# Altium schematic sheet Sch_front.SchDoc
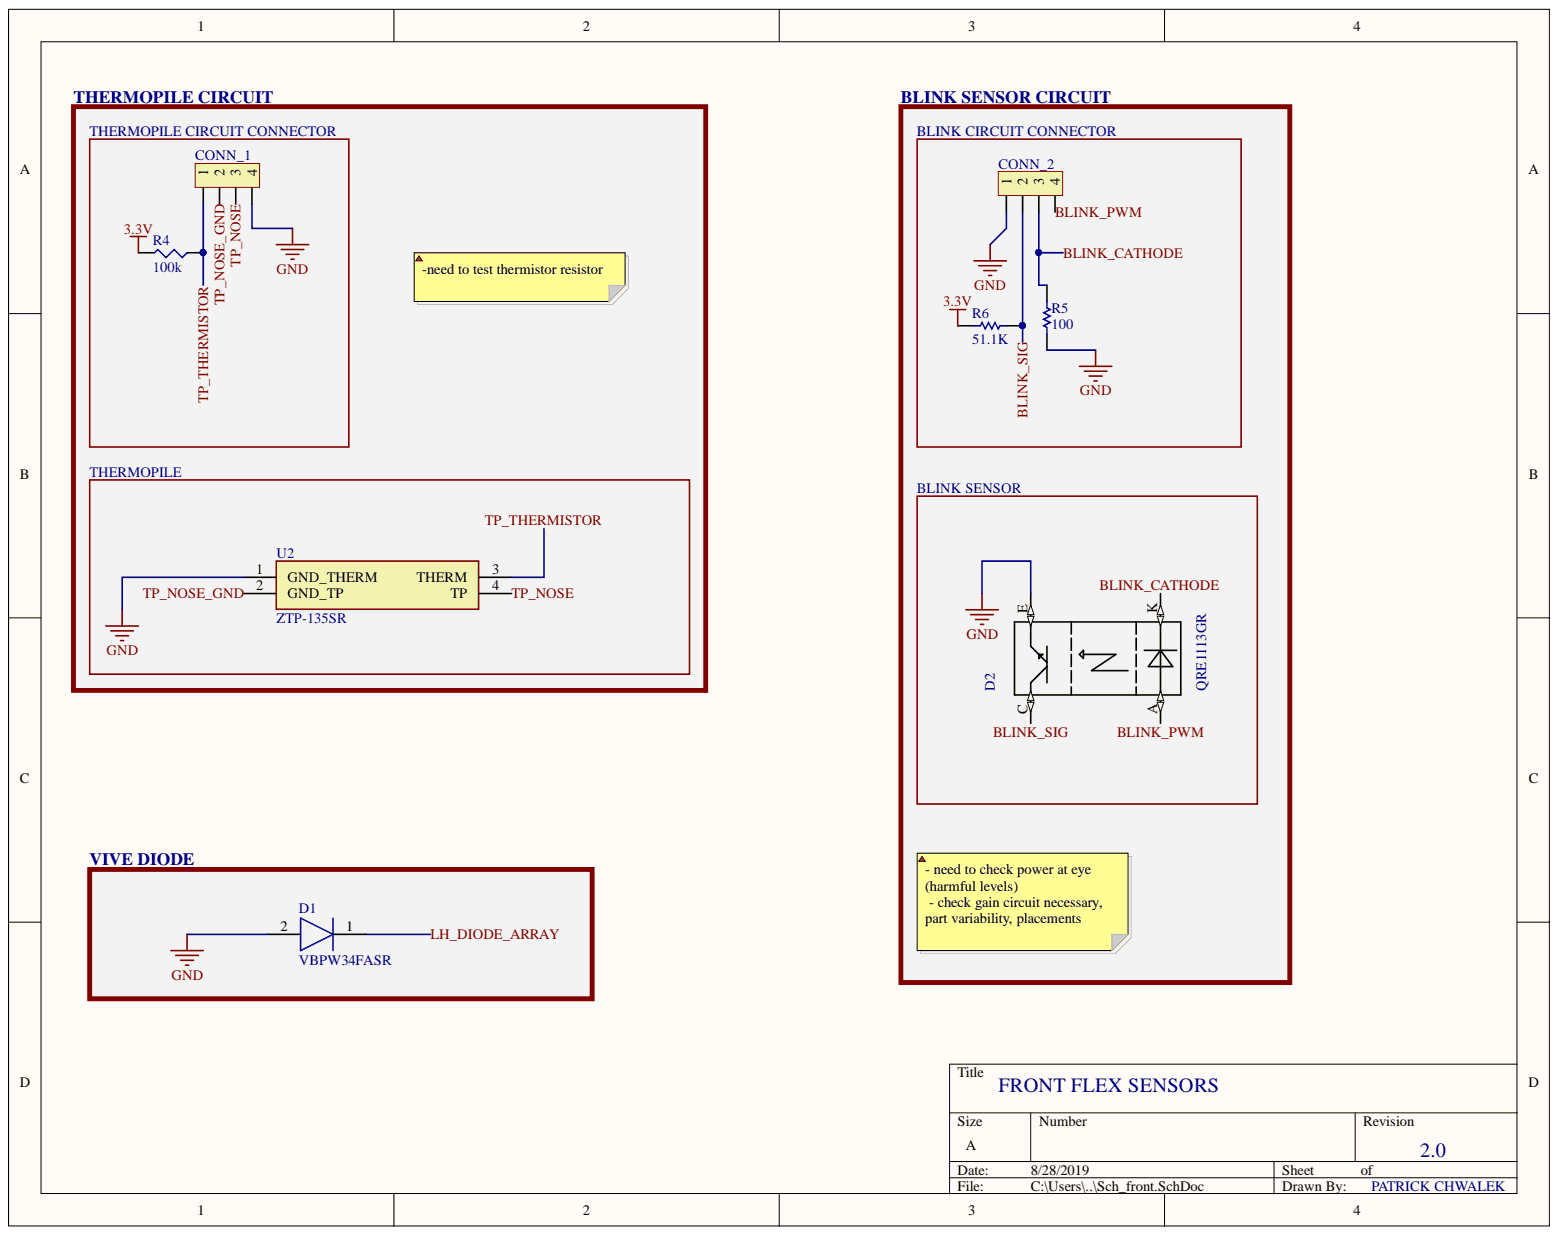
[diagram p0: Sch_front.SchDoc]

## Parts
CONN_1  THERMO  [4_pos_1mm_header]  (value not drawn)
CONN_2  BLINK  [4_pos_1mm_header]
D1  VBPW34FASR  [VBPW34FAS]
D2  QRE1113GR  [*4LEADSMD_QRE1113GR(Primary)]
R4  100k  [SMD-0402-RES]
R5  100  [0402R]
R6  51.1K  [0402R]
U2  ZTP-135SR  [ZTP-135SR]

## Nets
3.3V: R4.2 R6.2
GND: CONN_1.4 CONN_2.1 D1.2 D2.E R5.1 U2.1(GND_THERM)
BLINK_CATHODE: CONN_2.3 D2.K R5.2
BLINK_PWM: CONN_2.4 D2.A
BLINK_SIG: CONN_2.2 D2.C R6.1
LH_DIODE_ARRAY: D1.1
TP_NOSE: CONN_1.3 U2.4(TP)
TP_NOSE_GND: CONN_1.2 U2.2(GND_TP)
TP_THERMISTOR: CONN_1.1 R4.1 U2.3(THERM)

## Library data of the parts
CONN_1 CONN_2 = 4_pin_1mm_header: Component Kind: Standard; Library Name: schematic_components.SchLib
D1 = VBPW34FASR: Diode; Manufacturer_Name: Vishay; Component Kind: Standard; Datasheet Link: https://www.vishay.com/docs/81127/vbpw34fa.pdf; Height: 1.3mm; Manufacturer_Part_Number: VBPW34FASR; Mouser Part Number: 782-VBPW34FASR; Mouser Price/Stock: https://www.mouser.com/Search/Refine.aspx?Keyword=782-VBPW34FASR; RS Part Number: 7689401; RS Price/Stock: https://uk.rs-online.com/web/p/products/7689401
D2 = QRE1113GR: QRE1113 Series 30 V 50 mA Miniature Reflective Object Sensor - SMD-4; MF: ON Semiconductor; Availability: Unavailable; Component Kind: Standard; Library Name: QRE1113GR.SchLib; MP: QRE1113GR; Package: SMD-4 ON Semiconductor; Price: None; SnapEDA_Link: https://www.snapeda.com/parts/QRE1113GR/ON%20Semiconductor/view-part/?ref=snap
R4 = ERJ-2GEJ104X: RES SMD 100K OHM 5% 1/10W 0402; Manufacturer: Panasonic Electronic Components; @ qty: 1500; Factory Stock: 0; Minimum Quantity: 1; Power (Watts): 0.1W, 1/10W; Temperature Coefficient: ±200ppm/°C; Unit Price (USD): 0.00350; Component Kind: Standard; Composition: Thick Film; Datasheets: http://industrial.panasonic.com/www-cgi/jvcr13pz.cgi?E+PZ+3+AOA0001+ERJ2GEJ104X+7+WW; Digi-Key Part Number: P100KJCT-ND; Failure Rate: -; Features: Automotive AEC-Q200; Height - Seated (Max): 0.016" (0.40mm); Image: //media.digikey.com/Photos/Panasonic%20Photos/ERJ-PA2-Series.jpg; Library Name: Panasonic_ERJ_0402.IntLib; Number of Terminations: 2; Operating Temperature: -55°C ~ 155°C; Package / Case: 0402 (1005 Metric); Packaging: Cut Tape (CT); Part Number: ERJ-2GEJ104X; Part Status: Active; Quantity Available: 2387986; Series: ERJ; Size / Dimension: 0.039" L x 0.020" W (1.00mm x 0.50mm); Supplier Device Package: 0402; Tolerance: ±5%; Value: 100k
R5 = Resistor: Component Kind: Standard; Simulation: RESISTOR; Library Name: bdm.SchLib
R6 = Resistor: CRCW040251K1FKED; Component Kind: Standard; Simulation: RESISTOR; Library Name: bdm.SchLib
U2 = ZTP-135SR: Undefined or Miscellaneous; Manufacturer_Name: Amphenol Advanced Sensors; Allied_Number: 70282698; Allied Price/Stock: https://www.alliedelec.com/amphenol-advanced-sensors-ztp-135sr/70282698/; Component Kind: Standard; Datasheet Link: https://www.amphenol-sensors.com/en/component/edocman/370-thermopile-ir-sensor-applications/download?Itemid=7967; Library Name: SamacSys.SchLib; Manufacturer_Part_Number: ZTP-135SR; Mouser Part Number: 527-ZTP-135SR; Mouser Price/Stock: https://www.mouser.com/Search/Refine.aspx?Keyword=527-ZTP-135SR
